# Altium schematic sheet RPiLabBaseBoard.SchDoc
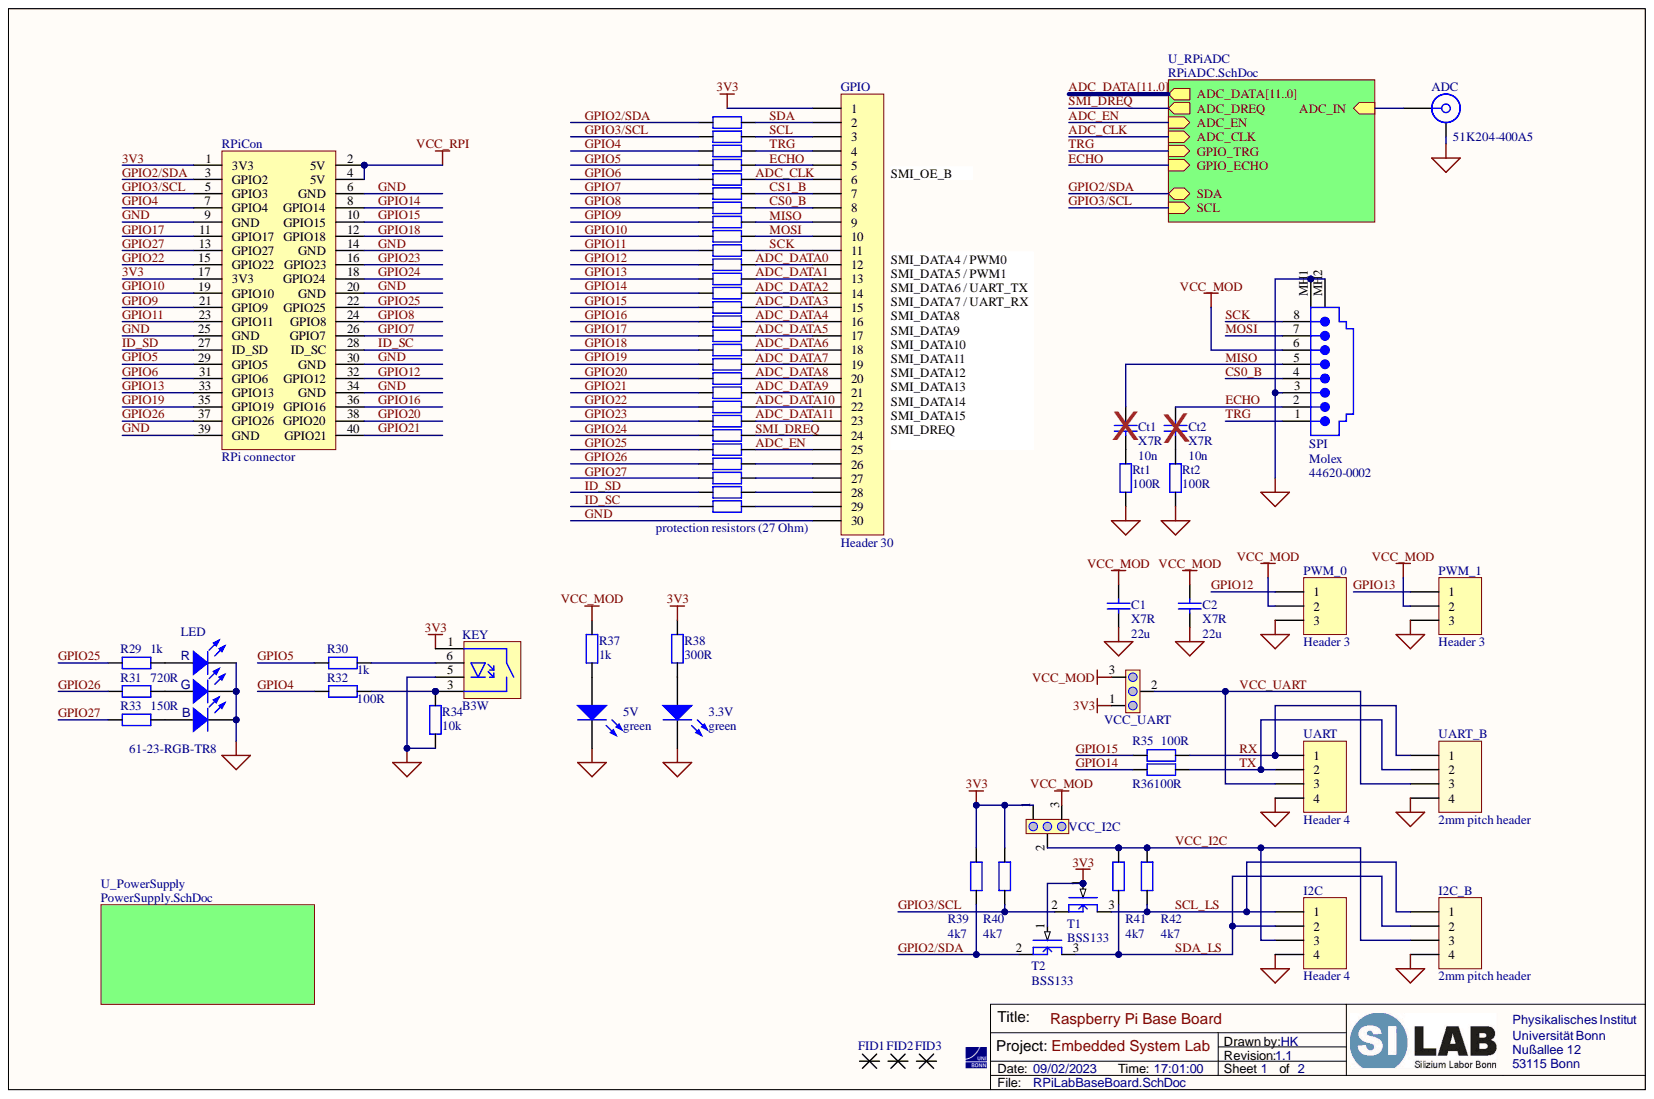
[diagram p0: RPiLabBaseBoard.SchDoc]

## Parts
1  Uni Logo  [Uni Logo Neu 15x15]  (value not drawn)
3.3V  green  [0603_A]
5V  green  [0603_A]
ADC  51K204-400A5  [ROS-51K204-400A5_V]
C1  X7R  value 22u  [C1210_imp_N]
C2  X7R  value 22u  [C1210_imp_N]
Ct1  X7R  value 10n  [C0603_imp_N]
Ct2  X7R  value 10n  [C0603_imp_N]
FID1  xxx  [Fiducial]  (value not drawn)
FID2  xxx  [Fiducial]  (value not drawn)
FID3  xxx  [Fiducial]  (value not drawn)
GPIO  Header 30  [HDR1X30]
I2C  Header 4  [901361304]
I2C_B  2mm pitch header  [62000411622]
KEY  B3W  [B3W-9 1LED]
LED  61-23-RGB-TR8  [PLCC-6]
PWM_0  Header 3  [HDR1X3]
PWM_1  Header 3  [HDR1X3]
R1  R  [R0603_imp_N]  (value not drawn)
R2  R  [R0603_imp_N]  (value not drawn)
R3  R  [R0603_imp_N]  (value not drawn)
R4  R  [R0603_imp_N]  (value not drawn)
R5  R  [R0603_imp_N]  (value not drawn)
R6  R  [R0603_imp_N]  (value not drawn)
R7  R  [R0603_imp_N]  (value not drawn)
R8  R  [R0603_imp_N]  (value not drawn)
R9  R  [R0603_imp_N]  (value not drawn)
R10  R  [R0603_imp_N]  (value not drawn)
R11  R  [R0603_imp_N]  (value not drawn)
R12  R  [R0603_imp_N]  (value not drawn)
R13  R  [R0603_imp_N]  (value not drawn)
R14  R  [R0603_imp_N]  (value not drawn)
R15  R  [R0603_imp_N]  (value not drawn)
R16  R  [R0603_imp_N]  (value not drawn)
R17  R  [R0603_imp_N]  (value not drawn)
R18  R  [R0603_imp_N]  (value not drawn)
R19  R  [R0603_imp_N]  (value not drawn)
R20  R  [R0603_imp_N]  (value not drawn)
R21  R  [R0603_imp_N]  (value not drawn)
R22  R  [R0603_imp_N]  (value not drawn)
R23  R  [R0603_imp_N]  (value not drawn)
R24  R  [R0603_imp_N]  (value not drawn)
R25  R  [R0603_imp_N]  (value not drawn)
R26  R  [R0603_imp_N]  (value not drawn)
R27  R  [R0603_imp_N]  (value not drawn)
R28  R  [R0603_imp_N]  (value not drawn)
R29  R  value 1k  [R0603_imp_N]
R30  R  value 1k  [R0603_imp_N]
R31  R  value 720R  [R0603_imp_N]
R32  R  value 100R  [R0603_imp_N]
R33  R  value 150R  [R0603_imp_N]
R34  R  value 10k  [R0603_imp_N]
R35  R  value 100R  [R0603_imp_N]
R36  R  value 100R  [R0603_imp_N]
R37  R  value 1k  [R0603_imp_N]
R38  R  value 300R  [R0603_imp_N]
R39  R  value 4k7  [R0603_imp_N]
R40  R  value 4k7  [R0603_imp_N]
R41  R  value 4k7  [R0603_imp_N]
R42  R  value 4k7  [R0603_imp_N]
RPiCon  RPi connector  [RPi 3B - dummy]
Rt1  R  value 100R  [R0603_imp_N]
Rt2  R  value 100R  [R0603_imp_N]
SPI  Comment_1: Molex  [44620-0002]  (hidden comment: -)
T1  BSS133  [SOT23]
T2  BSS133  [SOT23]
UART  Header 4  [901361304]
UART_B  2mm pitch header  [62000411622]
VCC_I2C  -  [solderjumper3 - large area]  (value not drawn)
VCC_UART  -  [solderjumper3 - large area]  (value not drawn)

## Nets
VCC_MOD: C1.1 C2.1 PWM_0.2 PWM_1.2 R37.2 SPI.6 VCC_I2C.3 VCC_UART.3
VCC_RPI: RPiCon.2 RPiCon.4
3V3: GPIO.1 KEY.1 R38.2 R39.2 R40.2 RPiCon.1 RPiCon.17 T1.1 T2.1 VCC_I2C.1 VCC_UART.1
ADC_CLK: GPIO.6 R5.2
ADC_DATA0: GPIO.12 R11.2  [also labelled ADC_DATA]
ADC_DATA1: GPIO.13 R12.2  [also labelled ADC_DATA]
ADC_DATA2: GPIO.14 R13.2  [also labelled ADC_DATA]
ADC_DATA3: GPIO.15 R14.2  [also labelled ADC_DATA]
ADC_DATA4: GPIO.16 R15.2  [also labelled ADC_DATA]
ADC_DATA5: GPIO.17 R16.2  [also labelled ADC_DATA]
ADC_DATA6: GPIO.18 R17.2  [also labelled ADC_DATA]
ADC_DATA7: GPIO.19 R18.2  [also labelled ADC_DATA]
ADC_DATA8: GPIO.20 R19.2  [also labelled ADC_DATA]
ADC_DATA9: GPIO.21 R20.2  [also labelled ADC_DATA]
ADC_DATA10: GPIO.22 R21.2  [also labelled ADC_DATA]
ADC_DATA11: GPIO.23 R22.2  [also labelled ADC_DATA]
ADC_EN: GPIO.25 R24.2
CS0_B: GPIO.8 R7.2 SPI.4
CS1_B: GPIO.7 R6.2
ECHO: Ct2.1 GPIO.5 R4.2 SPI.2
GND: 3.3V.1 5V.1 ADC.MH C1.2 C2.2 GPIO.30 I2C.4 I2C_B.4 KEY.5 LED.1 LED.2 LED.3 PWM_0.3 PWM_1.3 R34.1 RPiCon.6 RPiCon.9 RPiCon.14 RPiCon.20 RPiCon.25 RPiCon.30 RPiCon.34 RPiCon.39 Rt1.1 Rt2.1 SPI.3 SPI.MH1 SPI.MH2 UART.4 UART_B.4
GPIO2/SDA: R1.1 R39.1 RPiCon.3 T2.2
GPIO3/SCL: R2.1 R40.1 RPiCon.5 T1.2
GPIO4: R3.1 R32.1 RPiCon.7
GPIO5: R4.1 R30.2 RPiCon.29
GPIO6: R5.1 RPiCon.31
GPIO7: R6.1 RPiCon.26
GPIO8: R7.1 RPiCon.24
GPIO9: R8.1 RPiCon.21
GPIO10: R9.1 RPiCon.19
GPIO11: R10.1 RPiCon.23
GPIO12: PWM_0.1 R11.1 RPiCon.32
GPIO13: PWM_1.1 R12.1 RPiCon.33
GPIO14: R13.1 R36.1 RPiCon.8
GPIO15: R14.1 R35.1 RPiCon.10
GPIO16: R15.1 RPiCon.36
GPIO17: R16.1 RPiCon.11
GPIO18: R17.1 RPiCon.12
GPIO19: R18.1 RPiCon.35
GPIO20: R19.1 RPiCon.38
GPIO21: R20.1 RPiCon.40
GPIO22: R21.1 RPiCon.15
GPIO23: R22.1 RPiCon.16
GPIO24: R23.1 RPiCon.18
GPIO25: R24.1 R29.2 RPiCon.22
GPIO26: R25.1 R31.2 RPiCon.37
GPIO27: R26.1 R33.2 RPiCon.13
ID_SC: R28.1 RPiCon.28
ID_SD: R27.1 RPiCon.27
MISO: Ct1.1 GPIO.9 R8.2 SPI.5
MOSI: GPIO.10 R9.2 SPI.7
RX: R35.2 UART.1 UART_B.1
SCK: GPIO.11 R10.2 SPI.8
SCL: GPIO.3 R2.2
SCL_LS: I2C.1 I2C_B.1 R42.1 T1.3
SDA: GPIO.2 R1.2
SDA_LS: I2C.2 I2C_B.2 R41.1 T2.3
SMI_DREQ: GPIO.24 R23.2
TRG: GPIO.4 R3.2 SPI.1
TX: R36.2 UART.2 UART_B.2
VCC_I2C: I2C.3 I2C_B.3 R41.2 R42.2 VCC_I2C.2
VCC_UART: UART.3 UART_B.3 VCC_UART.2
Net3.3V_2: 3.3V.2 R38.1
Net5V_2: 5V.2 R37.1
NetADC_1: ADC.1
NetCt1_2: Ct1.2 Rt1.2
NetCt2_2: Ct2.2 Rt2.2
NetGPIO_26: GPIO.26 R25.2
NetGPIO_27: GPIO.27 R26.2
NetGPIO_28: GPIO.28 R27.2
NetGPIO_29: GPIO.29 R28.2
NetKEY_3: KEY.3 R32.2 R34.2
NetKEY_6: KEY.6 R30.1
NetLED_4: LED.4 R31.1
NetLED_5: LED.5 R29.1
NetLED_6: LED.6 R33.1

## Library data of the parts
3.3V 5V = CMP-1426-00002-1: SMD mono-color Chip LED, WL-SMCW, Green; Manufacturer: Wuerth Elektronik; PartNumber: 150060GS75000; Color: Green; Component Kind: Standard; ComponentLink1Description: Manufacturer URL; ComponentLink1URL: http://www.we-online.com; ComponentLink2Description: Datasheet; ComponentLink2URL: http://katalog.we-online.com/led/datasheet/150060GS75000.pdf; Library Name: Altium Content Vault; PackageReference: 0603_A
ADC = CMP-0753-00004-1: BNC 50R Right Angle Jack, Panel Feedthrough, Up to 10 GHz, -55 to 155 degC; Manufacturer: Rosenberger; Design Note: Footprint in library is a generic example - only application specific footprint delivers High Performance; Component Kind: Standard; ComponentLink1Description: Manufacturer URL; ComponentLink1URL: http://www.rosenberger.de; ComponentLink2Description: Datasheet; ComponentLink2URL: http://rosenberger.de/ok/images/documents/db/51K204-400A5.pdf; ComponentLink3Description: Package Specification; ComponentLink3URL: http://rosenberger.de/ok/images/documents/db/51K204-400A5.pdf; ComponentLink4Description: Series Overview / Design Note; ComponentLink4URL: http://rosenberger.de/ok/images/documents/spez/51.pdf; ComponentLink5Description: Webshop / RFQ; ComponentLink5URL: http://www.rosenberger.com/en/contact/sales/; DatasheetVersion: d00; Library Name: Altium Content Vault; Mounting Technology: Through Hole; PackageDescription: 50R BNC Right Angle Jack, 12.5 x 32.6 x 14.5 mm; PackageReference: 51K204-400A5; PackageVersion: d00; PartNumber: 51K204-400A5
C1 C2 Ct1 Ct2 = C: Capacitor; Class: Passive; Constraints: 6.3V; Type_1: SMD Chip Capacitor; Comment_1: -; Component Kind: Standard; HelpURL: -; LatestRevisionDate: 27-Nov-2007; PackageDescription: -; Signal Integrity: Capacitor; Simulation: Capacitor; Library Name: Silab_Lib.IntLib; Publisher: Silab J.S.
FID1-FID3 = Fiducial: Class: Passive; Comment_1: xxx; Component Kind: Standard; Constraints: xxx; Description: Fiducial; LatestRevisionDate: 09-Aug-2013; PackageDescription: xxx; Type_1: xxx; Value: xxx; HelpURL: http://www.   ...  or    X:\DXP\Datasheets\ ...; Library Name: Silab_Lib.IntLib; Publisher: Silab VF
GPIO = Header 30: Header, 30-Pin; Component Kind: Standard; LatestRevisionDate: 17-Jul-2002; LatestRevisionNote: Re-released for DXP Platform.; Library Name: Miscellaneous Connectors.IntLib; Signal Integrity: Connector; Publisher: Altium Limited
I2C UART = Header 4, shrouded: Molex 901361304; Component Kind: Standard; LatestRevisionDate: 17-Jul-2002; LatestRevisionNote: Re-released for DXP Platform.; Library Name: RPiLab.SchLib; Signal Integrity: Connector; Publisher: Altium Limited
I2C_B UART_B = 62000411622: WR-WTB 2.00mm Male Vertical Shrouded Header, 4p; Pin Number: 4; Component Kind: Standard; ComponentLink1Description: Manufacturer; ComponentLink1URL: http://www.we-online.de; ComponentLink2Description: Datasheet; ComponentLink2URL: http://katalog.we-online.de/em/datasheet/6200xx11622.pdf; Library Name: 6200xx11622 (rev17a).IntLib; Part Number: 62000411622; Pitch: 2.00 mm; Published Date: June 2017; Publisher: Wurth Elektronik
KEY = PushButton, illuminated: Illuminated Pushbutton, NO; Color: red; Component Kind: Standard; Type: B3W; Library Name: Microprozessor.IntLib
LED = 61-23-RGB-TR8: SMD RGB LED; Component Kind: Standard; Library Name: RGB.IntLib
PWM_0 PWM_1 = Header 3: Header, 3-Pin; Component Kind: Standard; LatestRevisionDate: 17-Jul-2002; LatestRevisionNote: Re-released for DXP Platform.; Library Name: Miscellaneous Connectors.IntLib; Signal Integrity: Connector; Publisher: Altium Limited
R1-R28 = R: SMD Chip Resistor; Class: Passive; Constraints: 1%; Value: 27R; Comment_1: -; Component Kind: Standard; HelpURL: -; LatestRevisionDate: 27-Nov-2007; PackageDescription: -; Signal Integrity: Resistor; Simulation: Resistor; Type_1: SMD Chip Resistor; Library Name: Silab_Lib.IntLib; Publisher: Silab J.S.
R29-R42 Rt1 Rt2 = R: SMD Chip Resistor; Class: Passive; Constraints: 1%; Comment_1: -; Component Kind: Standard; HelpURL: -; LatestRevisionDate: 27-Nov-2007; PackageDescription: -; Signal Integrity: Resistor; Simulation: Resistor; Type_1: SMD Chip Resistor; Library Name: Silab_Lib.IntLib; Publisher: Silab J.S.
RPiCon = RPi dummy: Component Kind: Standard; Library Name: RPiLab.SchLib
SPI = RJ-45 Buchse: Modular Jack, Right Angle RJ-45, Low Profile, Inverted, with all Panel Grounding Tabs, Shielded with RJ-11 Keepout Feature; Class: Connector; Component Kind: Standard; Constraints: -; HelpURL: http://www.molex.com/cmc_upload/common_files/1212003_mx44620.pdf; LatestRevisionDate: 24-Sep-2008; Signal Integrity: Modular Jack; Type_1: 44620-0002; Value: -; Library Name: Silab_Lib.IntLib; Publisher: Silab C.H.
T1 T2 = T NMOS: NMOS Transistor SMD; Class: Discrete Silicon; Comment_1: -; Component Kind: Standard; Constraints: -; HelpURL: -; LatestRevisionDate: 02-Oct-2008; PackageDescription: -; Type_1: BSS133; Value: -; Library Name: Silab_Lib.IntLib; Publisher: Silab J.S.
VCC_I2C VCC_UART = JUMPER 1X3S: Jumper, Solderbridge 1x3; Class: Connector; Comment_1: -; Component Kind: Standard; Constraints: -; HelpURL: -; LatestRevisionDate: 24-Sep-2008; PackageDescription: -; Type_1: -; Value: -; Library Name: Silab_Lib.IntLib; Publisher: Silab C.H.
1 = Uni Logo: Component Kind: Standard; Library Name: Silab_Lib.IntLib
